# Altium schematic sheet ICI2511_XG_V04_20170620.SchDoc
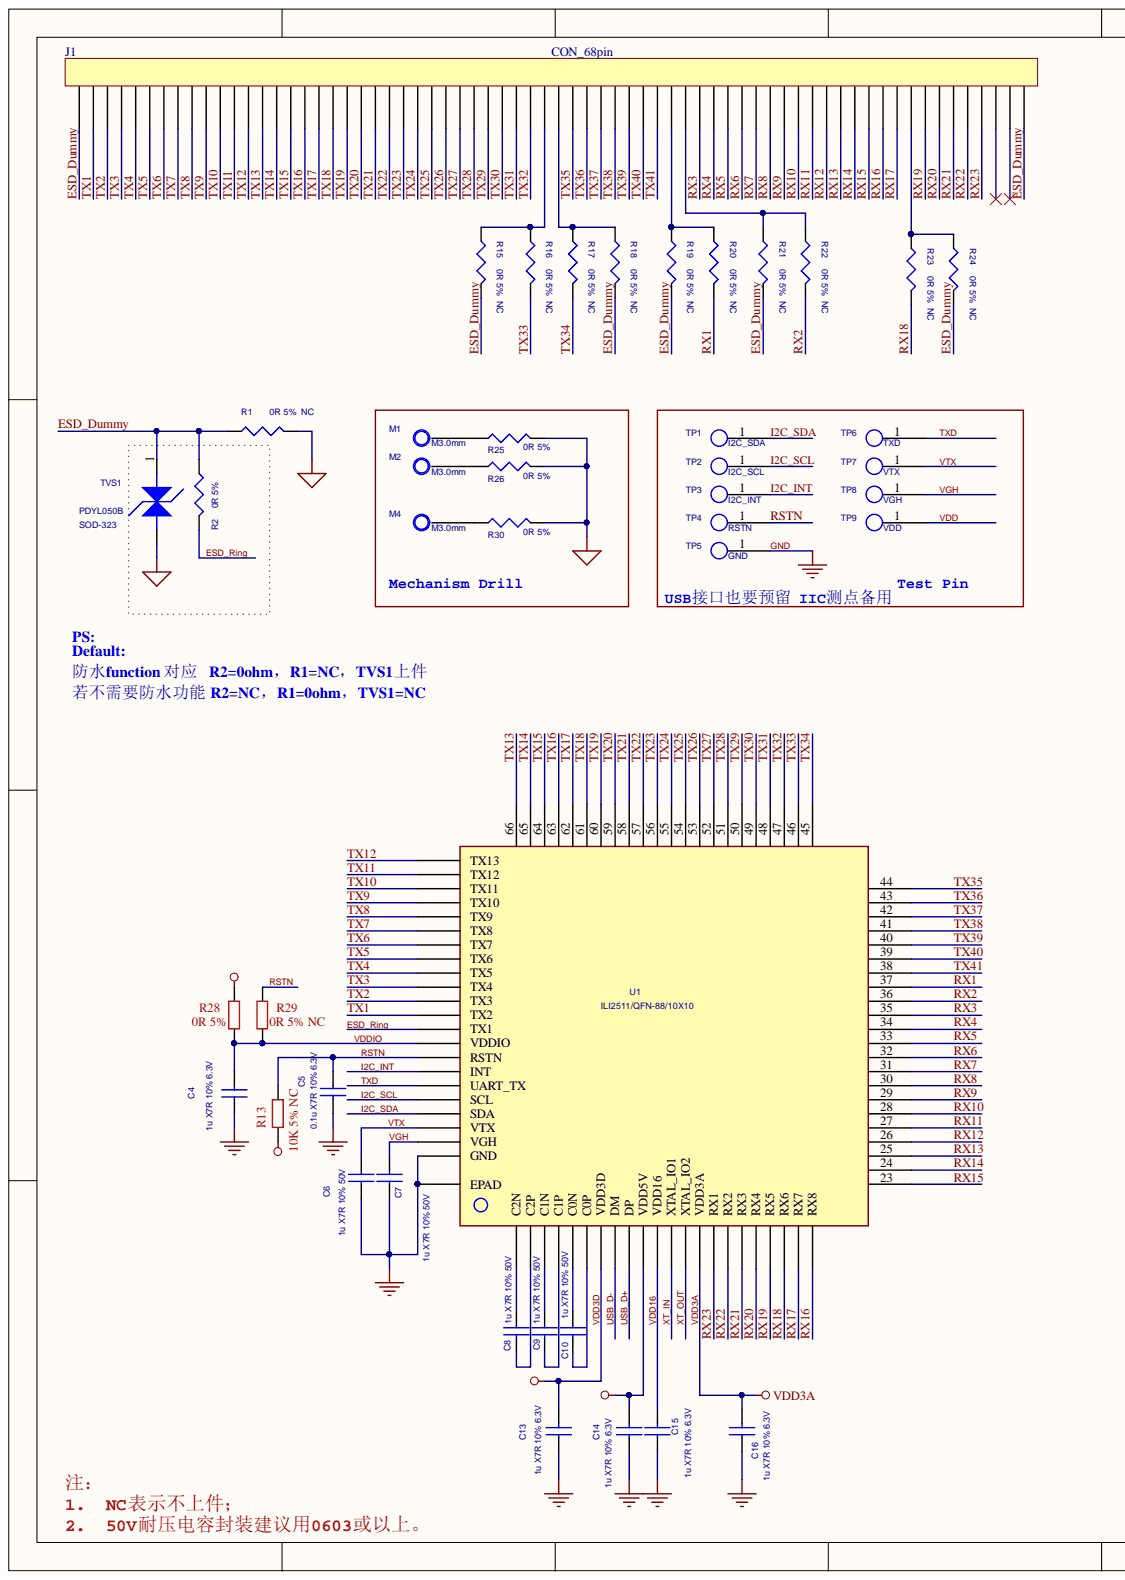
[diagram p0: ICI2511_XG_V04_20170620.SchDoc, left]
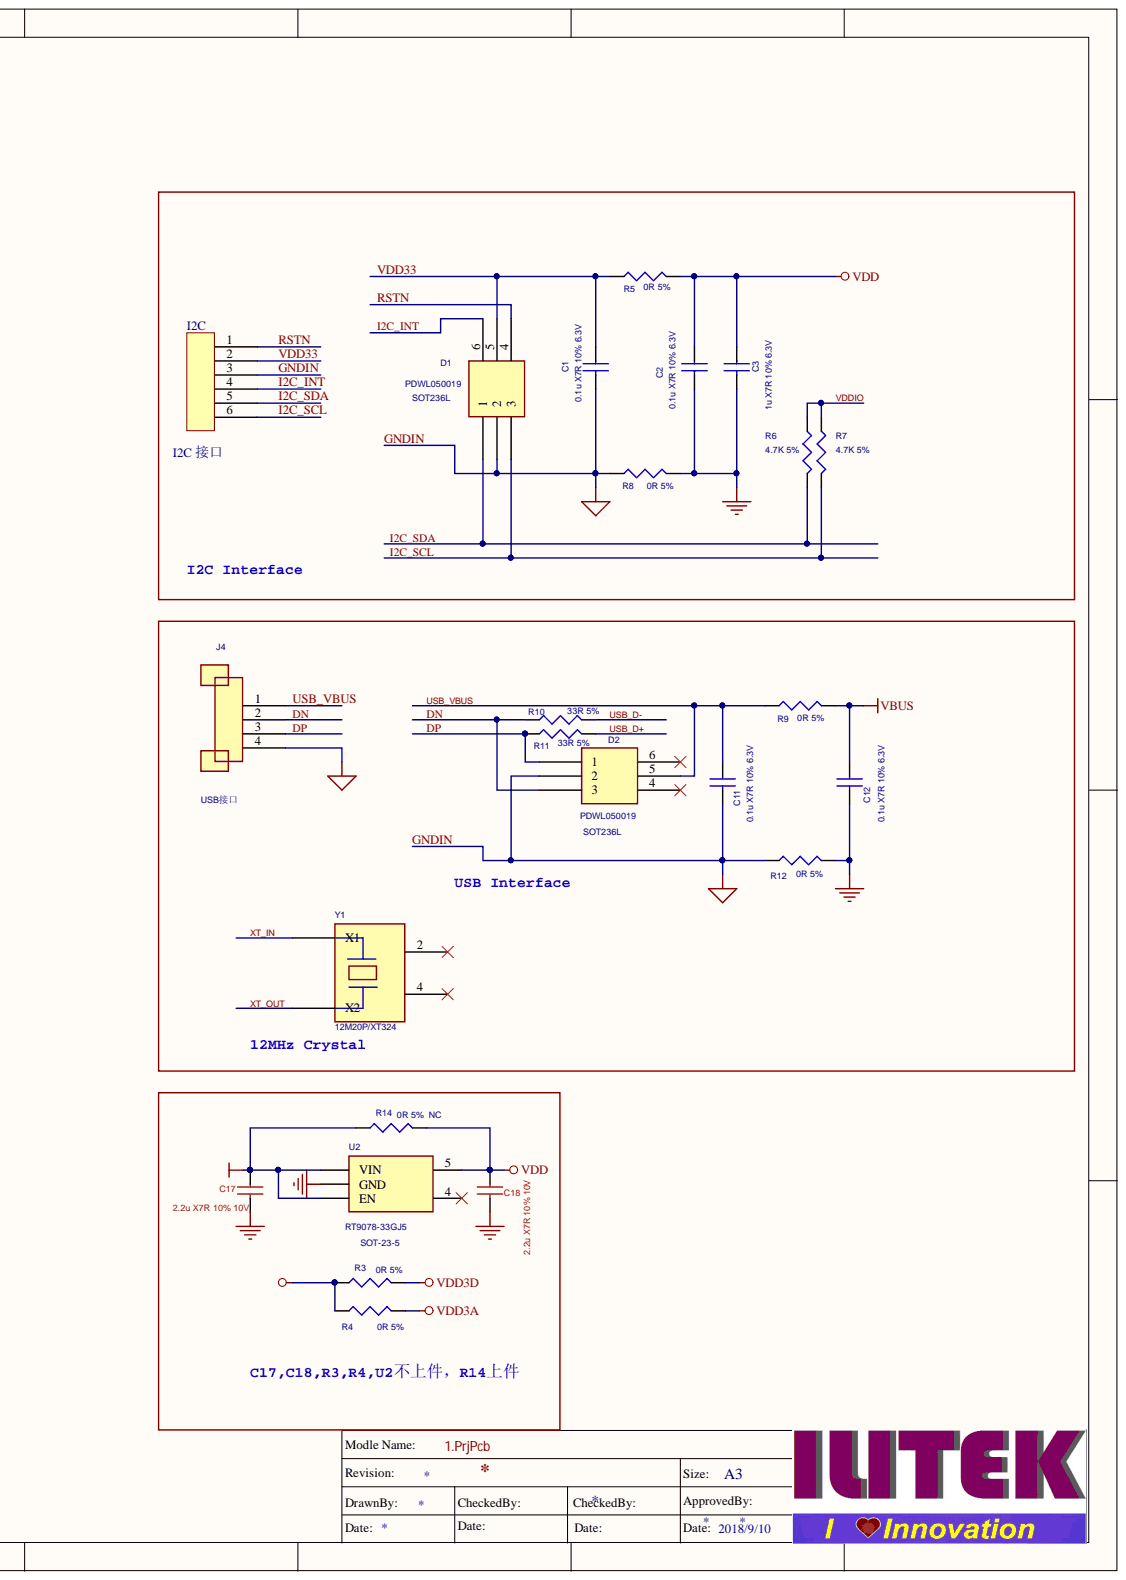
[diagram p1: ICI2511_XG_V04_20170620.SchDoc, right]

## Parts
C1  0.1u X7R 10% 6.3V  [C0402]
C2  0.1u X7R 10% 6.3V  [C0402]
C3  1u X7R 10% 6.3V  [C0402]
C4  1u X7R 10% 6.3V  [C0402]
C5  0.1u X7R 10% 6.3V  [C0402]
C6  1u X7R 10% 50V  Value2: X7R  [C0603]
C7  1u X7R 10% 50V  [C0603]
C8  1u X7R 10% 50V  Value2: X7R  [C0603]
C9  1u X7R 10% 50V  Value2: X7R  [C0603]
C10  1u X7R 10% 50V  Value2: X7R  [C0603]
C11  0.1u X7R 10% 6.3V  [C0402]
C12  0.1u X7R 10% 6.3V  [C0402]
C13  1u X7R 10% 6.3V  [C0402]
C14  1u X7R 10% 6.3V  [C0402]
C15  1u X7R 10% 6.3V  [C0402]
C16  1u X7R 10% 6.3V  [C0402]
C17  2.2u X7R 10% 10V  Value1: 10V  [C0402]
C18  2.2u X7R 10% 10V  [C0402]
D1  PDWL050019  Value1: SOT236L  [SOT236]
D2  PDWL050019  Value1: SOT236L  [SOT236]
I2C  I2C 接口  [I2C-6PIN]
J1  CON_68pin  [CON_68_3*0.3_0.2]
J4  USB接口  [USB_532610471_sd]
M1  M3.0mm  [VIA-5.5*5.5*3.5]
M2  M3.0mm  [VIA-5.5*5.5*3.5]
M4  M3.0mm  [VIA-5.5*5.5*3.5]
R1  0R 5%  NC  Value1: NC  [R0402]
R2  0R 5%  Value1: 0R  [R0402]
R3  0R 5%  Value1: 0R  [R0402]
R4  0R 5%  Value1: 0R  [R0402]
R5  0R 5%  Value1: 0R  [C0402]
R6  4.7K 5%  Value1: 4.7K  [R0402]
R7  4.7K 5%  Value1: 4.7K  [R0402]
R8  0R 5%  Value1: 0R  [C0402]
R9  0R 5%  Value1: 0R  [R0603]
R10  33R 5%  Value1: 33R  [R0402]
R11  33R 5%  Value1: 33R  [R0402]
R12  0R 5%  Value1: 0R  [R0603]
R13  10K 5% NC  value 10K  [C0402]
R14  0R 5%  NC  Value1: 0R  [R0402]
R15  0R 5%  NC  Value1: NC  [R0402]
R16  0R 5%  NC  Value1: NC  [R0402]
R17  0R 5%  NC  Value1: NC  [R0402]
R18  0R 5%  NC  Value1: NC  [R0402]
R19  0R 5%  NC  Value1: NC  [R0402]
R20  0R 5%  NC  Value1: NC  [R0402]
R21  0R 5%  NC  Value1: NC  [R0402]
R22  0R 5%  NC  Value1: NC  [R0402]
R23  0R 5%  NC  Value1: NC  [R0402]
R24  0R 5%  NC  Value1: NC  [R0402]
R25  0R 5%  Value1: 0R  [R0603]
R26  0R 5%  Value1: 0R  [R0603]
R28  0R 5%  [R0402]
R29  0R 5% NC  [R0402]
R30  0R 5%  Value1: 0R  [R0603]
TP1  I2C_SDA  [TP0]
TP2  I2C_SCL  [TP0]
TP3  I2C_INT  [TP0]
TP4  RSTN  [TP0]
TP5  GND  [TP0]
TP6  TXD  [TP0]
TP7  VTX  [TP0]
TP8  VGH  [TP0]
TP9  VDD  [TP0]
TVS1  PDYL050B  [SOD-323]
U1  ILI2511/QFN-88/10X10  [ILI2511/QFN-88/10X10]
U2  RT9078-33GJ5  [SOT-23-5]
Y1  12M20P/XT324  [12M20P/XT324]

## Nets
VBUS: C12.1 C17.1 R9.2 R14.1 U2.1(VIN) U2.3(EN)
DN: D2.3 J4.2 R10.1
DP: D2.1 J4.3 R11.1
ESD_Dummy: J1.1 J1.68 R1.1 R2.1 R15.2 R18.2 R19.2 R21.2 R24.2 TVS1.1
ESD_Ring: R2.2 U1.79(TX1)
GND: C2.2 C3.2 C4.1 C5.2 C6.1 C7.1 C12.2 C13.1 C14.1 C15.1 C16.1 C17.2 C18.2 R8.2 R12.2 TP5.1 U1.88(GND) U1.89(EPAD) U2.2(GND)
GNDIN: C1.2 C11.2 D1.2 D2.2 I2C.3 J4.4 R1.2 R8.1 R12.1 R25.2 R26.2 R30.2 TVS1.2
I2C_INT: D1.6 I2C.4 TP3.1 U1.82(INT)
I2C_SCL: D1.3 I2C.6 R7.2 TP2.1 U1.84(SCL)
I2C_SDA: D1.1 I2C.5 R6.2 TP1.1 U1.85(SDA)
RSTN: C5.1 D1.4 I2C.1 R13.1 R29.2 TP4.1 U1.81(RSTN)
RX1: R20.2 U1.37
RX2: R22.2 U1.36
RX3: J1.45 U1.35
RX4: J1.46 U1.34
RX5: J1.47 U1.33
RX6: J1.48 U1.32
RX7: J1.49 U1.31
RX8: J1.50 U1.30
RX9: J1.51 U1.29
RX10: J1.52 U1.28
RX11: J1.53 U1.27
RX12: J1.54 U1.26
RX13: J1.55 U1.25
RX14: J1.56 U1.24
RX15: J1.57 U1.23
RX16: J1.58 U1.22(RX8)
RX17: J1.59 U1.21(RX7)
RX18: R23.2 U1.20(RX6)
RX19: J1.61 U1.19(RX5)
RX20: J1.62 U1.18(RX4)
RX21: J1.63 U1.17(RX3)
RX22: J1.64 U1.16(RX2)
RX23: J1.65 U1.15(RX1)
TX1: J1.2 U1.78(TX2)
TX2: J1.3 U1.77(TX3)
TX3: J1.4 U1.76(TX4)
TX4: J1.5 U1.75(TX5)
TX5: J1.6 U1.74(TX6)
TX6: J1.7 U1.73(TX7)
TX7: J1.8 U1.72(TX8)
TX8: J1.9 U1.71(TX9)
TX9: J1.10 U1.70(TX10)
TX10: J1.11 U1.69(TX11)
TX11: J1.12 U1.68(TX12)
TX12: J1.13 U1.67(TX13)
TX13: J1.14 U1.66
TX14: J1.15 U1.65
TX15: J1.16 U1.64
TX16: J1.17 U1.63
TX17: J1.18 U1.62
TX18: J1.19 U1.61
TX19: J1.20 U1.60
TX20: J1.21 U1.59
TX21: J1.22 U1.58
TX22: J1.23 U1.57
TX23: J1.24 U1.56
TX24: J1.25 U1.55
TX25: J1.26 U1.54
TX26: J1.27 U1.53
TX27: J1.28 U1.52
TX28: J1.29 U1.51
TX29: J1.30 U1.50
TX30: J1.31 U1.49
TX31: J1.32 U1.48
TX32: J1.33 U1.47
TX33: R16.2 U1.46
TX34: R17.2 U1.45
TX35: J1.36 U1.44
TX36: J1.37 U1.43
TX37: J1.38 U1.42
TX38: J1.39 U1.41
TX39: J1.40 U1.40
TX40: J1.41 U1.39
TX41: J1.42 U1.38
TXD: TP6.1 U1.83(UART_TX)
USB_D+: R11.2 U1.9(DP)
USB_D-: R10.2 U1.8(DM)
USB_VBUS: C11.1 D2.5 J4.1 R9.1
VDD: C2.1 C3.1 C14.2 C18.1 R3.1 R4.1 R5.2 R14.2 TP9.1 U1.10(VDD5V) U2.5
VDD3A: C16.2 R4.2 U1.14(VDD3A)
VDD3D: C13.2 R3.2 R13.2 R28.2 U1.7(VDD3D)
VDD16: C15.2 U1.11(VDD16)
VDD33: C1.1 D1.5 I2C.2 R5.1
VDDIO: C4.2 R6.1 R7.1 R28.1 R29.1 U1.80(VDDIO)
VGH: C7.2 TP8.1 U1.87(VGH)
VTX: C6.2 TP7.1 U1.86(VTX)
XT_IN: U1.12(XTAL_IO1) Y1.1(X1)
XT_OUT: U1.13(XTAL_IO2) Y1.3(X2)
NetC8_1: C8.1 U1.1(C2N)
NetC8_2: C8.2 U1.2(C2P)
NetC9_1: C9.1 U1.3(C1N)
NetC9_2: C9.2 U1.4(C1P)
NetC10_1: C10.1 U1.5(C0N)
NetC10_2: C10.2 U1.6(C0P)
NetJ1_34: J1.34 R15.1 R16.1
NetJ1_35: J1.35 R17.1 R18.1
NetJ1_43: J1.43 R19.1 R20.1
NetJ1_44: J1.44 R21.1 R22.1
NetJ1_60: J1.60 R23.1 R24.1
NetM1_1: M1.1 R25.1
NetM2_1: M2.1 R26.1
NetM4_1: M4.1 R30.1

Not connected: D2 4 6; J1 66 67; U2 4; Y1 2 4

## Library data of the parts
C1 C2 C11 C12 = C0402/0.1u/6.3V: 贴片电容; PartNumber: 00226020.1661; Value1: 0.1uF/6.3V; Value2: X5R; Vendor: Faithful LINK; Component Kind: Standard
C3 = C0402/0.1u/6.3V: 贴片电容; PartNumber: 00226020.1661; Value1: 1uF/6.3V; Value2: X5R; Vendor: Faithful LINK; Component Kind: Standard
C4 C13-C16 = C0402_2.2u_6.3V_X5R: 贴片电容; PartNumber: 002260222561; Value1: 1uF/6.3V; Value2: X5R; Vendor: Faithful LINK; Component Kind: Standard
C5 = C0402_1u_6.3V_X5R: 贴片电容; PartNumber: 00226021661; Value1: 0.1uF/6.3V; Value2: X5R; Vendor: Faithful LINK; Component Kind: Standard
C6 C7 = C0603_1u_50V_X7R: 贴片电容; PartNumber: 002260310544; Value1: 4.7uF/50V; Vendor: Faithful LINK; Component Kind: Standard
  C7: Value2: X7R
C8-C10 = C0603_1u_50V_X7R: 贴片电容; PartNumber: 002260310544; Value1: 1uF/50V; Vendor: Faithful LINK; Component Kind: Standard
C17 C18 = C0603_4.7u_10V_10%_X5R: 贴片电容; PartNumber: 002260347542; Value2: 10% X5R; Vendor: Faithful LINK; Component Kind: Standard
D1 D2 = D_PDWL050019-SOT236L_0.95_1.25: 贴片TVS; PartNumber: 00707551PDWL050019; Vendor: NichTek; Component Kind: Standard
I2C = CON6: Component Kind: Standard; Library Name: ILI_IC.SchLib
J4 = CON_50224-00501-001_1.0_2.9: PartNumber: 006460505250224; Vendor: Aces; Component Kind: Standard; Library Name: ICI2511_XG_V04_20170620.SCHLIB
M1 M2 M4 = Drill_M3.0: PartNumber: Drill3.0MM; Vendor: ILITEK; Component Kind: Standard
R1 R15-R24 = R0402NC: 贴片电阻; PartNumber: 0010502NC; Value2: ∮5%; Vendor: YAGEO; Component Kind: Standard
R2 = R0402_0R_+-5%: 贴片电阻; PartNumber: 00105020003; Value2: ∮5%; Vendor: YAGEO; Component Kind: Standard
R3 R4 R14 = R0402_0R_+-5%_Dup2: 贴片电阻; PartNumber: 00105020003; Value2: ∮5%; Vendor: YAGEO; Component Kind: Standard
R5 R8 R9 R12 R25 R26 R30 = R0805_0R_+-5%: 贴片电阻; PartNumber: 00126040003; Value2: ∮5%; Vendor: Faithful LINK; Component Kind: Standard
R6 R7 = R0402_4.7K_+-5%: 贴片电阻; PartNumber: 00126024723; Value2: ∮5%; Vendor: Faithful LINK; Component Kind: Standard
R10 R11 = R0402_33R_+-5%: 贴片电阻; PartNumber: 00105023303; Value2: ∮5%; Vendor: YAGEO; Component Kind: Standard
R13 = Res2: 贴片电阻; Component Kind: Standard; LatestRevisionDate: 17-Jul-2002; LatestRevisionNote: Re-released for DXP Platform.; Library Name: Miscellaneous Devices.IntLib; PackageDescription: Axial Device, Thru-Hole; 2 Leads; 0.4 in Pin Spacing; PackageReference: R0603; Published: 8-Jun-2000; Publisher: Altium Limited
R28 R29 = Res2: 贴片电阻; Value: 10K; Component Kind: Standard; LatestRevisionDate: 17-Jul-2002; LatestRevisionNote: Re-released for DXP Platform.; Library Name: Miscellaneous Devices.IntLib; PackageDescription: Axial Device, Thru-Hole; 2 Leads; 0.4 in Pin Spacing; PackageReference: R0603; Published: 8-Jun-2000; Publisher: Altium Limited
TP1-TP3 TP6-TP9 = TEST POINT: Vendor: ILITEK; Component Kind: Standard; PartNumber: TEST POINT
TP4 TP5 = TEST POINT_Dup1: Vendor: ILITEK; Component Kind: Standard; PartNumber: TEST POINT
TVS1 = D_PDYL050B_SOD-323_2.23_1: 贴片TVS; PartNumber: 00707102050B; Vendor: NichTek; Component Kind: Standard; Value1: SOD-323
U1 = ILI2511/QFN-88/10X10_0.4_0.9: 奕力IC; Part Name: 005122ILI2511; Vendor: ILITEK; Component Kind: Standard
U2 = G9091-330THU/SOT-23-5/0.95/1.45: 5-3.3vLDO; PartNumber: '0053032059091; Vendor: GMT; Component Kind: Standard; Value1: SOT-23-5
Y1 = X_12M20P_XT324_2.2_0.6: 贴片晶振; PartNumber: 00425312000020; Vendor: YIC; Component Kind: Standard
J1 = CON_68pin: Component Kind: Standard; Description: CON_68pin; Library Name: ICI2511M.SCHLIB
